# Altium schematic sheet dsPIC Click Analog v1.6.SchDoc
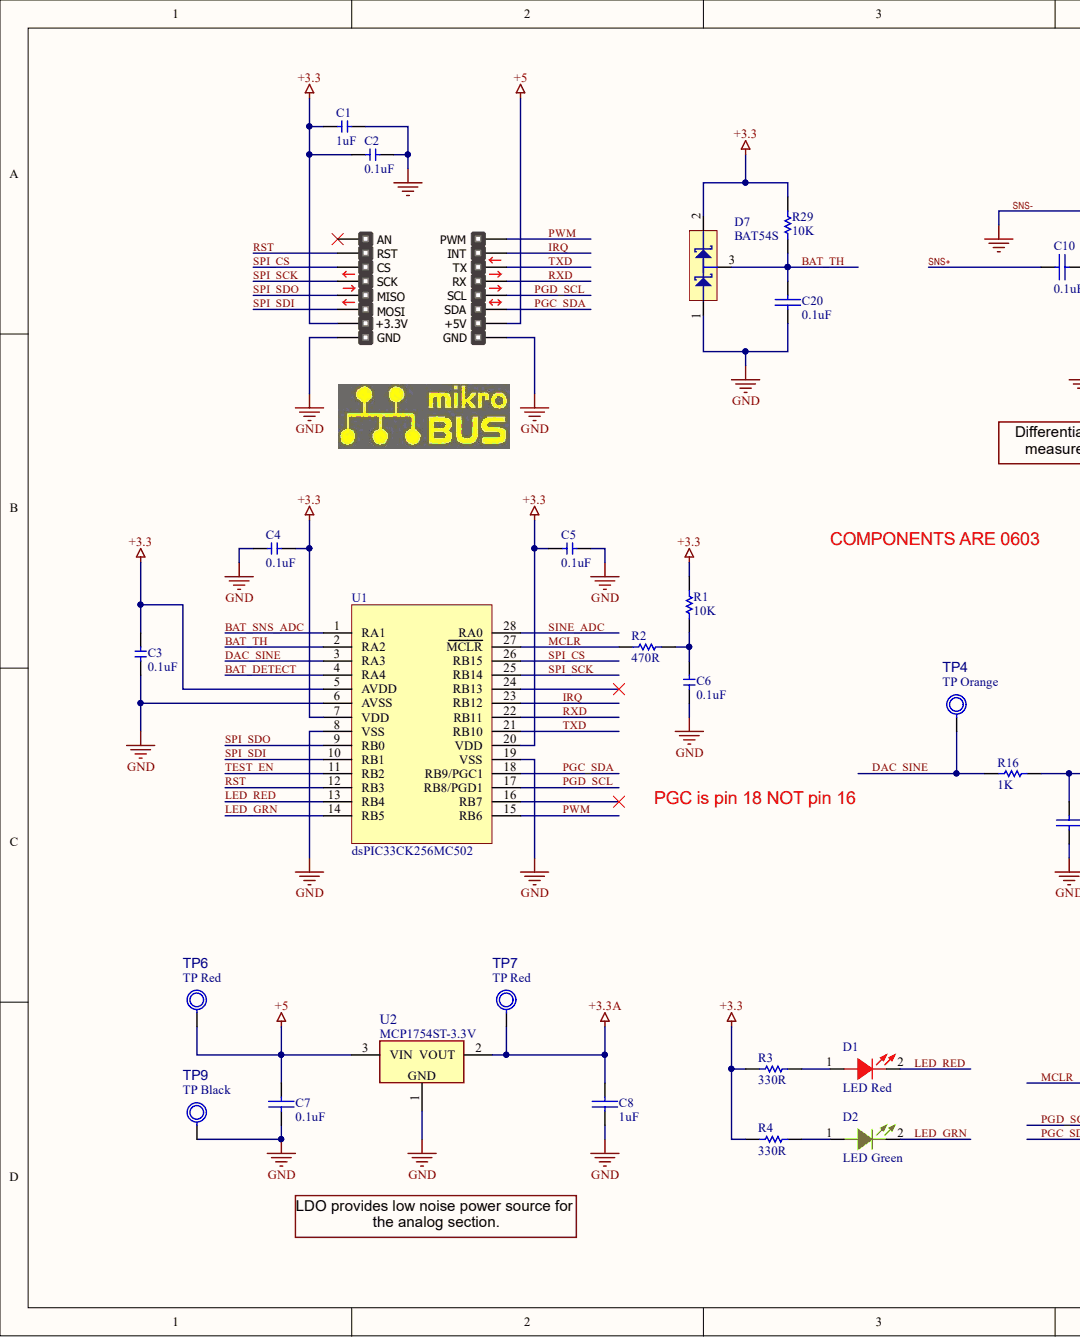
[diagram p0: dsPIC Click Analog v1.6.SchDoc, left]
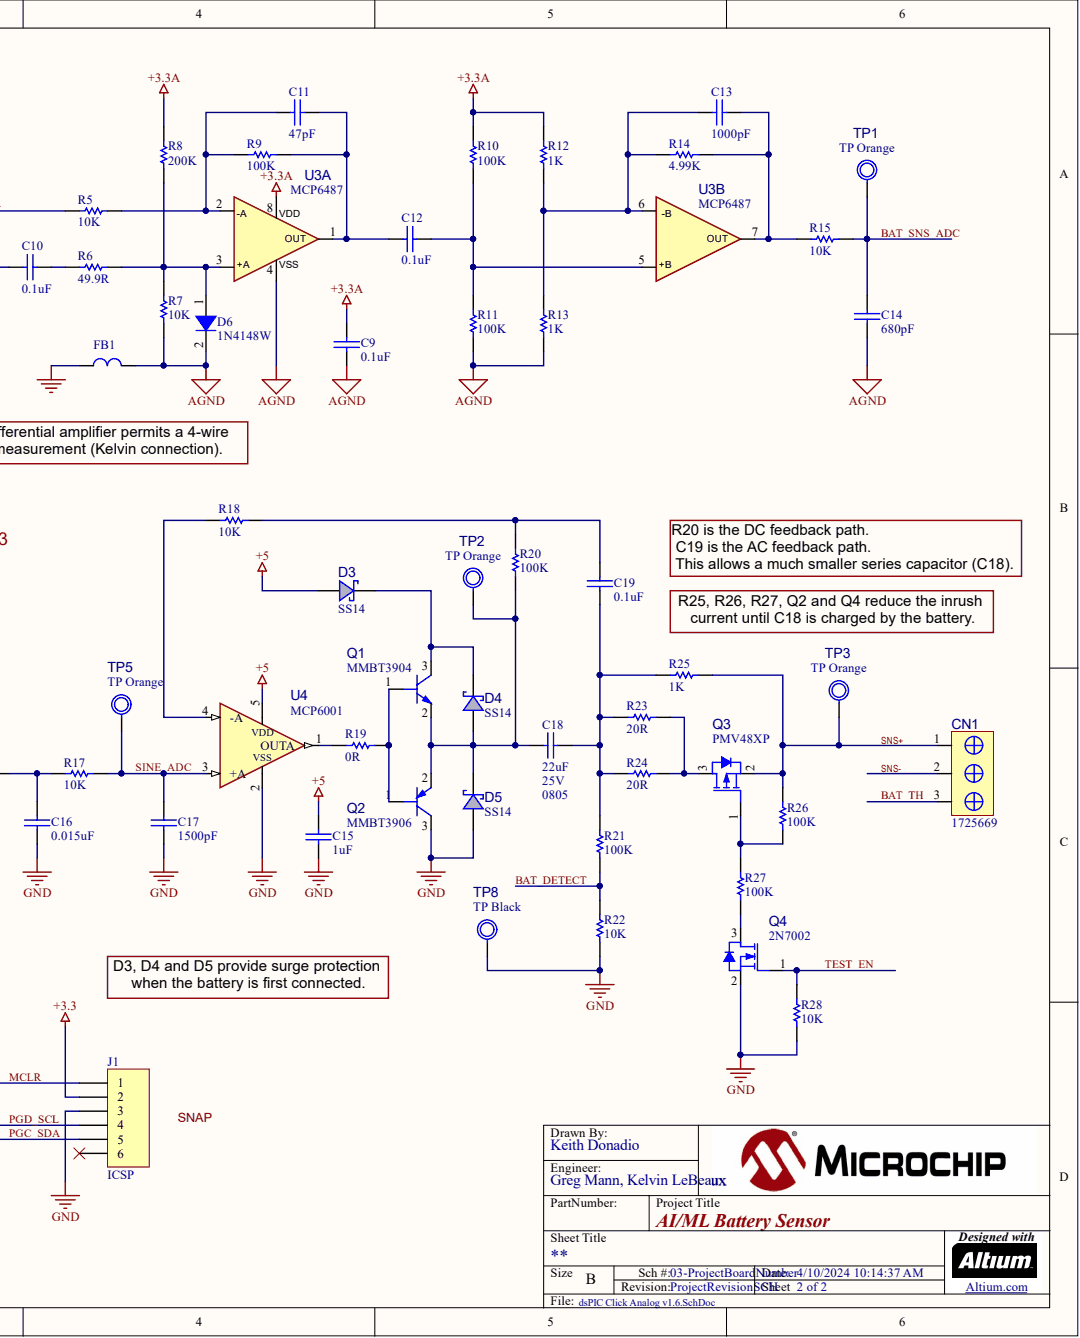
[diagram p1: dsPIC Click Analog v1.6.SchDoc, right]

## Parts
C1  1uF  [0603C]
C2  0.1uF  [0603C]
C3  0.1uF  [0603C]
C4  0.1uF  [0603C]
C5  0.1uF  [0603C]
C6  0.1uF  [0603C]
C7  0.1uF  [0603C]
C8  1uF  [0603C]
C9  0.1uF  [0603C]
C10  0.1uF  [0603C]
C11  47pF  [0603C]
C12  0.1uF  [0603C]
C13  1000pF  [0603C]
C14  680pF  [0603C]
C15  1uF  [0603C]
C16  0.015uF  [0603C]
C17  1500pF  [0603C]
C18  22uF  [0805C]
C19  0.1uF  [0603C]
C20  0.1uF  [0603C]
CN1  1725669  [1725669]
D1  LED Red  [LTST-C171]
D2  LED Green  [LTST-C171]
D3  SS14  [SMA-FL]
D4  SS14  [SMA-FL]
D5  SS14  [SMA-FL]
D6  1N4148W  [SOD-123]
D7  BAT54S  [SOT-23]
FB1  FB  [0603FB]
J1  ICSP  [HDR1X6]
MIKROBUS_CONNECTOR  MIKROBUS DEVICE CONN.  [MIKRO_BUS_dsPIC_T]  (value not drawn)
Q1  MMBT3904  [SOT-23]
Q2  MMBT3906  [SOT-23]
Q3  PMV48XP  [SOT-23]
Q4  2N7002  [SOT-23]
R1  10K  [0603R]
R2  470R  [0603R]
R3  330R  [0603R]
R4  330R  [0603R]
R5  10K  [0603R]
R6  49.9R  [0603R]
R7  10K  [0603R]
R8  200K  [0603R]
R9  100K  [0603R]
R10  100K  [0603R]
R11  100K  [0603R]
R12  1K  [0603R]
R13  1K  [0603R]
R14  4.99K  [0603R]
R15  10K  [0603R]
R16  1K  [0603R]
R17  10K  [0603R]
R18  10K  [0603R]
R19  0R  [0603R]
R20  100K  [0603R]
R21  100K  [0603R]
R22  10K  [0603R]
R23  20R  [0603R]
R24  20R  [0603R]
R25  1K  [0603R]
R26  100K  [0603R]
R27  100K  [0603R]
R28  10K  [0603R]
R29  10K  [0603R]
TP1  Test Point Orange  [TP_KEYSTONE_5003]  (value not drawn)
TP2  Test Point Orange  [TP_KEYSTONE_5003]  (value not drawn)
TP3  Test Point Orange  [TP_KEYSTONE_5003]  (value not drawn)
TP4  Test Point Orange  [TP_KEYSTONE_5003]  (value not drawn)
TP5  Test Point Orange  [TP_KEYSTONE_5003]  (value not drawn)
TP6  Test Point Red  [TP_KEYSTONE_5000]  (value not drawn)
TP7  Test Point Red  [TP_KEYSTONE_5000]  (value not drawn)
TP8  Test Point Black  [TP_KEYSTONE_5001]  (value not drawn)
TP9  Test Point Black  [TP_KEYSTONE_5001]  (value not drawn)
U1  dsPIC33CK256MC502  [SSOP-28]
U2  MCP1754ST-3.3V  [SOT-23]
U3  MCP6487  [SOIC-8]  (parts A,B)
U4  MCP6001  [TSOP-5]
  MikroBusLogo  [MB_Large]  (value not drawn)

## Nets
+3.3: C1.1 C2.1 C3.2 C4.1 C5.2 D7.2 J1.2 MIKROBUS_CONNECTOR.7(+3.3V) R1.2 R3.2 R4.2 R29.2 U1.5(AVDD) U1.7(VDD) U1.20(VDD)
+3.3A: C8.1 C9.1 R8.2 R10.2 R12.2 TP7.1 U2.2 U3.8
+5: C7.1 C15.1 D3.1 MIKROBUS_CONNECTOR.10(+5V) TP6.1 U2.3 U4.5
AGND: C9.2 C14.1 D6.2 FB1.2 R7.1 R11.1 R13.1 U3.4
BAT_DETECT: R21.1 R22.2 U1.4(RA4)
BAT_SNS_ADC: C14.2 R15.1 TP1.1 U1.1(RA1)
BAT_TH: C20.1 CN1.3 D7.3 R29.1 U1.2(RA2)
DAC_SINE: R16.1 TP4.1 U1.3(RA3)
IRQ: MIKROBUS_CONNECTOR.15(INT) U1.23(RB12)
LED_GRN: D2.2 U1.14(RB5)
LED_RED: D1.2 U1.13(RB4)
MCLR: J1.1 R2.2 U1.27(MCLR)
PGC_SDA: J1.5 MIKROBUS_CONNECTOR.11(SDA) U1.18(RB9/PGC1)
PGD_SCL: J1.4 MIKROBUS_CONNECTOR.12(SCL) U1.17(RB8/PGD1)
PWM: MIKROBUS_CONNECTOR.16(PWM) U1.15(RB6)
RST: MIKROBUS_CONNECTOR.2(RST) U1.12(RB3)
RXD: MIKROBUS_CONNECTOR.13(RX) U1.22(RB11)
SINE_ADC: C17.1 R17.2 TP5.1 U1.28(RA0) U4.3(+A)
SNS+: C10.1 CN1.1 Q3.2 R25.1 R26.1 TP3.1
SNS-: C1.2 C2.2 C3.1 C4.2 C5.1 C6.2 C7.2 C8.2 C15.2 C16.2 C17.2 C20.2 CN1.2 D5.1 D7.1 FB1.1 J1.3 MIKROBUS_CONNECTOR.8(GND) MIKROBUS_CONNECTOR.9(GND) Q2.3 Q4.2 R5.1 R22.1 R28.2 TP8.1 TP9.1 U1.6(AVSS) U1.8(VSS) U1.19(VSS) U2.1 U4.2
SPI_CS: MIKROBUS_CONNECTOR.3(CS) U1.26(RB15)
SPI_SCK: MIKROBUS_CONNECTOR.4(SCK) U1.25(RB14)
SPI_SDI: MIKROBUS_CONNECTOR.6(MOSI) U1.10(RB1)
SPI_SDO: MIKROBUS_CONNECTOR.5(MISO) U1.9(RB0)
TEST_EN: Q4.1 R28.1 U1.11(RB2)
TXD: MIKROBUS_CONNECTOR.14(TX) U1.21(RB10)
NetC6_1: C6.1 R1.1 R2.1
NetC10_2: C10.2 R6.1
NetC11_1: C11.1 R5.2 R9.2 U3.2(-A)
NetC11_2: C11.2 C12.1 R9.1 U3.1(OUT)
NetC12_2: C12.2 R10.1 R11.2 U3.5(+B)
NetC13_1: C13.1 R12.1 R13.2 R14.2 U3.6(-B)
NetC13_2: C13.2 R14.1 R15.2 U3.7(OUT)
NetC16_1: C16.1 R16.2 R17.1
NetC18_1: C18.1 D4.1 D5.2 Q1.2 Q2.2 R20.1 TP2.1
NetC18_2: C18.2 C19.2 R21.2 R23.2 R24.2 R25.2
NetC19_1: C19.1 R18.2 R20.2
NetD1_1: D1.1 R3.1
NetD2_1: D2.1 R4.1
NetD3_2: D3.2 D4.2 Q1.3
NetD6_1: D6.1 R6.2 R7.2 R8.1 U3.3(+A)
NetQ1_1: Q1.1 Q2.1 R19.2
NetQ3_1: Q3.1 R26.2 R27.1
NetQ3_3: Q3.3 R23.1 R24.1
NetQ4_3: Q4.3 R27.2
NetR18_1: R18.1 U4.4(-A)
NetR19_1: R19.1 U4.1(OUTA)

Not connected: J1 6; MIKROBUS_CONNECTOR 1(AN); U1 16(RB7) 24(RB13)

## Library data of the parts
C1-C6 = (no symbol name): Capacitor; Type: Ceramic; Category: Capacitor; Component Kind: Standard; ComponentLink1Description: Datasheet; Library Name: dsPIC Click_2.SCHLIB; Operating Temperature: -55C to +125C; Quantity Override: 1; Revision: 0
C7-C20 = CAP: Capacitor; Component Kind: Standard; Library Name: dsPIC Click_2.SCHLIB
  C18: : 0805; : 25V
CN1 = (no symbol name): Terminal Block, 3-Position; Manufacturer 1: Phoenix Contact; Manufacturer Part Number 1: 1725672; Current: 6A; Description_: CON TERMINAL 2.54mm 1x4 Female 20-30AWG 6A TH R/A; Dev Tools Status: Production; MCHP-ID: CON0005; Owner: Sorin Stanoaia; Populated: YES; Symbol: Header 1x4; Value: TERMINAL 1x4; Voltage: 63V; Category: Connector; Component Kind: Standard; ComponentLink1Description: SVN Datasheet; ComponentLink1URL: https://chn-vm-apps.microchip.com/mchprepo/parts/Datasheets/1725672.pdf; ComponentLink2Description: Local Datasheet; ComponentLink3Description: Open Symbol; ComponentLink4Description: Open Footprint; ComponentLink5Description: Open Footprint 2; ComponentLink6Description: Open Footprint 3; ComponentLink7Description: Open Footprint 4; Library Name: dsPIC Click_2.SCHLIB; Manufacturer 2: Degson Electronics Co., Ltd.; Manufacturer Part Number 2: DG308-2.54-04P-14-00A(H); Quantity Override: 1; Revision: 0; Status: MCL Design; Supplier 1: Digi-Key; Supplier 2: Raynen; Supplier Part Number 1: 277-1275-ND; Supplier Part Number 2: CONN00186B01M; Type: Terminal Block; ComponentLink2URL: C:\ALTIUM_WORK\00001_MCHP-CDB\Datasheets\1725672.pdf; ComponentLink3URL: C:\ALTIUM_WORK\00001_MCHP-CDB\Library\LibraryRefs\Header 1x4.SchLib; ComponentLink4URL: C:\ALTIUM_WORK\00001_MCHP-CDB\Library\Footprints\CON_TERMINAL_2.54_1x4_TH_RA_PHO_1725672.PcbLib
D1 = (no symbol name): Red LED; Manufacturer 1: Lumex; Manufacturer Part Number 1: SML-LXT0805IW-TR; Description_: DIO LED RED 2V 30mA 0805; Forward Current: 30mA; MCHP-ID: LED1020; Owner: Stephen Humberd; Populated: YES; Power: 50mW; Reverse Current: 100uA; Reverse Voltage: 5V; Type: LED Single; Category: Diode; Component Kind: Standard; ComponentLink1Description: Datasheet; ComponentLink1URL: https://chn-vm-apps.microchip.com/mchprepo/parts/Datasheets/SML-LXT0805IW-TR.pdf; Library Name: dsPIC Click_2.SCHLIB; Operating Temperature: -25C to +80C; Package: 0805; Quantity Override: 1; Revision: A; Status: Design; Supplier 1: Digi-Key; Supplier Part Number 1: 67-1552-1-ND; Value: RED
D2 = (no symbol name): Green LED; Manufacturer 1: Lumex; Manufacturer Part Number 1: SML-LXT0805IW-TR; Description_: DIO LED RED 2V 30mA 0805; Forward Current: 30mA; MCHP-ID: LED1020; Owner: Stephen Humberd; Populated: YES; Power: 50mW; Reverse Current: 100uA; Reverse Voltage: 5V; Type: LED Single; Value: GRN; Category: Diode; Component Kind: Standard; ComponentLink1Description: Datasheet; ComponentLink1URL: https://chn-vm-apps.microchip.com/mchprepo/parts/Datasheets/SML-LXT0805IW-TR.pdf; Library Name: dsPIC Click_2.SCHLIB; Operating Temperature: -25C to +80C; Package: 0805; Quantity Override: 1; Revision: A; Status: Design; Supplier 1: Digi-Key; Supplier Part Number 1: 67-1552-1-ND
D3-D5 = (no symbol name): Diode, Schottky; Manufacturer 1: Fairchild; Description_: DIO SCTKY SS14 500mV 1A 40V DO-214AC_SMA; Dev Tools Status: Production; Forward Current: 1A; MCHP-ID: DIODE0128; Populated: YES; Power: 1.1W; Reverse Current: 200uA; Reverse Voltage: 40V; Symbol: Diode Schottky; Type: Schottky Single; Category: Diode; Component Kind: Standard; ComponentLink1Description: SVN Datasheet; ComponentLink1URL: https://chn-vm-apps.microchip.com/mchprepo/parts/Datasheets/SS12.pdf; ComponentLink2Description: Local Datasheet; ComponentLink3Description: Open Symbol; ComponentLink4Description: Open Footprint; ComponentLink5Description: Open Footprint 2; ComponentLink6Description: Open Footprint 3; ComponentLink7Description: Open Footprint 4; Library Name: dsPIC Click_2.SCHLIB; Manufacturer Part Number 1: SS14; Operating Temperature: -65C to +125C; Package: DO-214AC_SMA; Quantity Override: 1; Revision: 1; Status: MCL Design; Supplier 1: Digi-Key; Supplier Part Number 1: SS14CT-ND; ComponentLink2URL: C:\ALTIUM_WORK\00001_MCHP-CDB\Datasheets\SS12.pdf; ComponentLink3URL: C:\ALTIUM_WORK\00001_MCHP-CDB\Library\LibraryRefs\Diode Schottky.SchLib; ComponentLink4URL: C:\ALTIUM_WORK\00001_MCHP-CDB\Library\Footprints\DO-214AC_SMA.PcbLib
D6 = 1N4148W: 1N4148W, SOD-123; Component Kind: Standard; Library Name: dsPIC Click_2.SCHLIB
D7 = BAT54S: Schottky Diode, Dual, Common Cathode; Code_JEDEC: DO-214-AA; Component Kind: Standard; LatestRevisionDate: 17-Jul-2002; LatestRevisionNote: Re-released for DXP Platform.; Library Name: dsPIC Click_2.SCHLIB; PackageDescription: DO-214-AA/SMB; 2 C-Bend Leads; Body 5.3 x 3.6 mm, inc. leads (LxW); PackageReference: SMB; Published: 8-Jun-2000; Publisher: Altium Limited
FB1 = (no symbol name): Ferrite Bead; Manufacturer 1: TDK; Manufacturer Part Number 1: FLF3215T-4R7M; DCR: 0.09R; Description_: INDUCTOR 4.7uH 1A 20% SMD 1210; MCHP-ID: IND0071; Populated: Yes; Saturation Current: 1A; Test Frequency: 1MHz; Type: Inductor; Category: Inductor; Component Kind: Standard; ComponentLink1Description: Datasheet; ComponentLink1URL: https://chn-vm-apps.microchip.com/mchprepo/parts/Datasheets/e531_flf3215.pdf; Library Name: dsPIC Click_2.SCHLIB; Operating Temperature: -40C to +125C; Package: 1210; Quantity Override: 1; Revision: 1; Status: Production; Supplier 1: Digi-Key; Supplier Part Number 1: 445-4846-2-ND
J1 = Header 6: Header, 6-Pin; Component Kind: Standard; LatestRevisionDate: 17-Jul-2002; LatestRevisionNote: Re-released for DXP Platform.; Library Name: dsPIC Click_2.SCHLIB; Publisher: Altium Limited
MIKROBUS_CONNECTOR = MIKROBUS DEVICE CONN.: mikroBUS Target Header; Component Kind: Standard; Library Name: dsPIC Click_2.SCHLIB
Q1 = MMBT3904: Transistor, NPN; Manufacturer 1: Diodes Incorporated; Manufacturer Part Number 1: MMBT3904-7; Current: 200mA; Description_: TRANS BJT NPN MMBT3904 40V 200mA 310mW SOT-23-3; Dev Tools Status: Production; MCHP-ID: TRA0006; Populated: Yes; Power: 310mW; Symbol: Transistor NPN BEC; Type: BJT; Voltage: 40V; Category: Transistor; Component Kind: Standard; ComponentLink1Description: SVN Datasheet; ComponentLink1URL: https://chn-vm-apps.microchip.com/mchprepo/parts/Datasheets/ds30036.pdf; ComponentLink2Description: Local Datasheet; ComponentLink3Description: Open Symbol; ComponentLink4Description: Open Footprint; ComponentLink5Description: Open Footprint 2; ComponentLink6Description: Open Footprint 3; ComponentLink7Description: Open Footprint 4; Library Name: dsPIC Click_2.SCHLIB; Manufacturer 2: Diodes Incorporated; Manufacturer 3: Fairchild; Manufacturer 4: Micro Commercial Components Corporation; Manufacturer 5: NXP Semiconductors; Manufacturer 6: ON Semiconductor; Manufacturer 7: ON Semiconductor; Manufacturer 8: ON Semiconductor; Manufacturer 9: STMicroelectronics; Manufacturer Part Number 2: MMBT3904-7-F; Manufacturer Part Number 3: MMBT3904; Manufacturer Part Number 4: MMBT3904-TP; Manufacturer Part Number 5: PMBT3904,215; Manufacturer Part Number 6: MMBT3904LT1G; Manufacturer Part Number 7: MMBT3904LT3G; Manufacturer Part Number 8: MMBT3904LT3; Manufacturer Part Number 9: MMBT3904; Operating Temperature: -55C to +150C; Package: SOT-23-3; Quantity Override: 1; Revision: 3; Status: MCL Design; Supplier 1: Digi-Key; Supplier 2: Digi-Key; Supplier 3: Digi-Key; Supplier 4: Digi-Key; Supplier 5: Digi-Key; Supplier 6: Digi-Key; Supplier 7: Digi-Key; Supplier 8: Digi-Key; Supplier 9: Digi-Key; Supplier 10: Digi-Key; Supplier Part Number 1: MMBT3904TPMSCT-ND; Supplier Part Number 2: MMBT3904-FDITR-ND; Supplier Part Number 3: MMBT3904-FDICT-ND; Supplier Part Number 4: MMBT3904FSTR-ND; Supplier Part Number 5: MMBT3904TPMSCT-ND; Supplier Part Number 6: 568-1741-1-ND; Supplier Part Number 7: MMBT3904LT1GOSCT-ND; Supplier Part Number 8: MMBT3904LT3GOSTR-ND; Supplier Part Number 9: MMBT3904LT3OSCT-ND; Supplier Part Number 10: 497-2478-2-ND; ComponentLink2URL: C:\ALTIUM_WORK\00001_MCHP-CDB\Datasheets\ds30036.pdf; ComponentLink3URL: C:\ALTIUM_WORK\00001_MCHP-CDB\Library\LibraryRefs\Transistor NPN BEC.SchLib; ComponentLink4URL: C:\ALTIUM_WORK\00001_MCHP-CDB\Library\Footprints\SOT-23-3.PcbLib
Q2 = MMBT3906: Transistor, PNP; Manufacturer 1: NXP Semiconductors; Manufacturer Part Number 1: PMBT3906,215; Current: -200mA; Description_: TRANS BJT PNP MMBT3906 -40V -200mA 300mW SOT-23-3; Dev Tools Status: Production; MCHP-ID: TRA0015; Populated: YES; Power: 300mW; Symbol: Transistor PNP BEC; Type: BJT; Voltage: -40V; Category: Transistor; Component Kind: Standard; ComponentLink1Description: SVN Datasheet; ComponentLink1URL: https://chn-vm-apps.microchip.com/mchprepo/parts/Datasheets/ds30059.pdf; ComponentLink2Description: Local Datasheet; ComponentLink3Description: Open Symbol; ComponentLink4Description: Open Footprint; ComponentLink5Description: Open Footprint 2; ComponentLink6Description: Open Footprint 3; ComponentLink7Description: Open Footprint 4; Library Name: dsPIC Click_2.SCHLIB; Manufacturer 2: Fairchild; Manufacturer 3: Micro Commercial Components Corporation; Manufacturer 4: Central Semiconductor; Manufacturer 5: Diodes Incorporated; Manufacturer 6: Diodes Incorporated; Manufacturer 7: ON Semiconductor; Manufacturer 8: Fairchild; Manufacturer Part Number 2: MMBT3906; Manufacturer Part Number 3: MMBT3906-TP; Manufacturer Part Number 4: CMPT3906-PST-LEADFREE; Manufacturer Part Number 5: MMBT3906-7; Manufacturer Part Number 6: MMBT3906-7-F; Manufacturer Part Number 7: MMBT3906LT1G; Manufacturer Part Number 8: MMBT3906K; Operating Temperature: -55C to +150C; Package: SOT-23-3; Quantity Override: 1; Revision: 2; Status: MCL Design; Supplier 1: Digi-Key; Supplier 2: Digi-Key; Supplier 3: Digi-Key; Supplier 4: Central Semiconductor; Supplier 5: Digi-Key; Supplier 6: Digi-Key; Supplier 7: Diodes Incorporated; Supplier 8: Digi-Key; Supplier 9: Fairchild; Supplier Part Number 1: 568-1742-1-ND; Supplier Part Number 2: MMBT3906FSTR-ND; Supplier Part Number 3: MMBT3906-TPCT-ND; Supplier Part Number 4: CMPT3906-PST-LEADFREE; Supplier Part Number 5: MMBT3906DITR-ND; Supplier Part Number 6: MMBT3906-FDICT-ND; Supplier Part Number 7: MMBT3906-7-F; Supplier Part Number 8: MMBT3906LT1GOSTR-ND; Supplier Part Number 9: MMBT3906K; ComponentLink2URL: C:\ALTIUM_WORK\00001_MCHP-CDB\Datasheets\ds30059.pdf; ComponentLink3URL: C:\ALTIUM_WORK\00001_MCHP-CDB\Library\LibraryRefs\Transistor PNP BEC.SchLib; ComponentLink4URL: C:\ALTIUM_WORK\00001_MCHP-CDB\Library\Footprints\SOT-23-3.PcbLib
Q3 = PMV48XP: MOSFET, P-Channel; Manufacturer 1: NXP Semiconductors; Manufacturer Part Number 1: PMV48XP,215; Current: 3.5A; Description_: TRANS FET P-CH PMV48XP,215 20V 3.5A 510mW SOT-23-3; Dev Tools Status: Design; Drain to Source Voltage: 20V; Gate to Source Voltage: 12V; MCHP-ID: TRA1141; Owner: Gabor Bihari; Populated: YES; Power: 510mW; Symbol: Transistor MOS-P GSD; Type: FET; Category: Transistor; Component Kind: Standard; ComponentLink1Description: SVN Datasheet; ComponentLink1URL: https://chn-vm-apps.microchip.com/mchprepo/parts/Datasheets/PMV48XP.pdf; ComponentLink2Description: Local Datasheet; ComponentLink3Description: Open Symbol; ComponentLink4Description: Open Footprint; ComponentLink5Description: Open Footprint 2; ComponentLink6Description: Open Footprint 3; ComponentLink7Description: Open Footprint 4; Library Name: dsPIC Click_2.SCHLIB; Operating Temperature: -55°C to 150°C; Package: SOT-23-3; Quantity Override: 1; Revision: A; Status: MCL Design; Supplier 1: Digi-Key; Supplier Part Number 1: 568-7579-1-ND; ComponentLink2URL: C:\ALTIUM_WORK\00001_MCHP-CDB\Datasheets\PMV48XP.pdf; ComponentLink3URL: C:\ALTIUM_WORK\00001_MCHP-CDB\Library\LibraryRefs\Transistor MOS-P GSD.SchLib; ComponentLink4URL: C:\ALTIUM_WORK\00001_MCHP-CDB\Library\Footprints\SOT-23-3.PcbLib
Q4 = 2N7002: MOSFET; Manufacturer 1: Diodes Inc; Manufacturer Part Number 1: 2N7002-7-F; Current: 170mA; Description_: TRANS FET N-CH 2N7002-7-F 60V 170mA 370mW SOT-23-3; Dev Tools Status: Production; Drain to Source Voltage: 60V; Gate to Source Voltage: 20V; MCHP-ID: TRA1102; Owner: Adrian M (M91404); Populated: YES; Power: 370mW; Symbol: Transistor MOS-N GSD; Type: FET; Category: Transistor; Component Kind: Standard; ComponentLink1Description: SVN Datasheet; ComponentLink1URL: https://chn-vm-apps.microchip.com/mchprepo/parts/Datasheets/ds11303.pdf; ComponentLink2Description: Local Datasheet; ComponentLink3Description: Open Symbol; ComponentLink4Description: Open Footprint; ComponentLink5Description: Open Footprint 2; ComponentLink6Description: Open Footprint 3; ComponentLink7Description: Open Footprint 4; Library Name: dsPIC Click_2.SCHLIB; Operating Temperature: -55C to +150C; Package: SOT-23-3; Quantity Override: 1; Revision: 0; Status: MCL Design; Supplier 1: Digi-Key; Supplier Part Number 1: 2N7002-FDICT-ND; ComponentLink2URL: C:\ALTIUM_WORK\00001_MCHP-CDB\Datasheets\ds11303.pdf; ComponentLink3URL: C:\ALTIUM_WORK\00001_MCHP-CDB\Library\LibraryRefs\Transistor MOS-N GSD.SchLib; ComponentLink4URL: C:\ALTIUM_WORK\00001_MCHP-CDB\Library\Footprints\SOT-23-3.PcbLib
R1-R29 = Res1: Resistor; Component Kind: Standard; LatestRevisionDate: 17-Jul-2002; LatestRevisionNote: Re-released for DXP Platform.; Library Name: dsPIC Click_2.SCHLIB; PackageDescription: Axial Device, Thru-Hole; 2 Leads; 0.3 in Pin Spacing; PackageReference: AXIAL-0.3; Published: 8-Jun-2000; Publisher: Altium Limited
TP1-TP5 = (no symbol name): Test Point, 5003; : TP Orange; Component Kind: Standard; Library Name: dsPIC Click_2.SCHLIB; Revision: 0
TP6 TP7 = (no symbol name): Test Point, 5000; : TP Red; Component Kind: Standard; Library Name: dsPIC Click_2.SCHLIB; Revision: 0
TP8 TP9 = (no symbol name): Test Point, 5001; : TP Black; Component Kind: Standard; Library Name: dsPIC Click_2.SCHLIB; Revision: 0
U1 = dsPIC33CK256MC502_CORRECTED: 16-bit MCU; Component Kind: Standard; Library Name: dsPIC Click_2.SCHLIB
U2 = (no symbol name): LDO; Manufacturer 1: Microchip Technology; Manufacturer Part Number 1: MCP1754ST-3302E/CB; Alarm_Mem: False; Description_: MCHP ANALOG LDO 3.3V MCP1754ST-3302E/CB SOT-23A-3; Dev Tools Status: Production; LeadCount: 3; MAPS Description: 16V, High PSRR, 150mA LDO, Vout=3.3V; MCHP-ID: MIC7325; MPC: V0AB2YM7XB00; Owner: Iulian Margarit; PackingMedia: T/R; Populated: YES; Symbol: MCP1754S SOT-23A-3; tblDeviceFamilyID: 3163; TempRange: -40C to +125C; Brown_Out: False; Category: MCHP-Analog; Chamber_Test: False; Charge_Pump: False; Component Kind: Standard; ComponentLink1Description: SVN Datasheet; ComponentLink1URL: http://www.microchip.com/wwwproducts/MCP1754S; ComponentLink2Description: Local Datasheet; ComponentLink3Description: Open Symbol; ComponentLink4Description: Open Footprint; ComponentLink5Description: Open Footprint 2; ComponentLink6Description: Open Footprint 3; ComponentLink7Description: Open Footprint 4; EncryptAlg: False; Inductive_Boost: False; InputChEnable: False; Interconnect: False; IntroductionDate: 2011-08-16; LED_Driver: False; LFTalkFeture: False; Library Name: dsPIC Click_2.SCHLIB; Low_Bat: False; Low_Batt: False; PackageType: SOT-23A; Power_Good: False; ProgWkUpFilter: False; Quantity Override: 1; RailIn: False; RailOut: False; Rev_Batt_Prot: False; Revision: 1; Sens_Timer: False; Status: MCL Design; Supplier 1: Digi-Key; Supplier Part Number 1: MCP1754ST-3302E/CBCT-ND; Type: LDO; WkUpPtnEnable: False; ComponentLink3URL: C:\ALTIUM_WORK\00001_MCHP-CDB\Library\LibraryRefs\MCP1754S SOT-23A-3.SchLib; ComponentLink4URL: C:\ALTIUM_WORK\00001_MCHP-CDB\Library\Footprints\SOT-23A-3.PcbLib
U3 = (no symbol name): OPAMP, Dual; Manufacturer 1: Microchip; Manufacturer Part Number 1: MCP6002T-I/SN; Alarm_Mem: False; Amplifiers: 2; Description_: MCHP ANALOG OPAMP 2-Ch 1MHz MCP6002T-I/SN SOIC-8; Dev Tools Status: Production; GBWP_KHz: 1000; IqMax: 170; LeadCount: 8; MAPS Description: Dual 1.8V, 1MHz OP, I temp; MCHP-ID: MIC0395; MPC: ABBZ1TC2XA00; PackageWidth: 3.90mm(.150in); PackingMedia: T/R; Populated: Yes; RailIn: True; StableGainMin: 1; Symbol: MCP6XX2_7 SOIC-8_MSOP-8_PDIP-8; tblDeviceFamilyID: 263; TempRange: -40C to +85C; VDDmax: 5.5; VDDmin: 1.8; VosMax: 4500; Brown_Out: False; Category: MCHP-Analog; Chamber_Test: False; Charge_Pump: False; Component Kind: Standard; ComponentLink1Description: SVN Datasheet; ComponentLink1URL: http://www.microchip.com/wwwproducts/MCP6002; ComponentLink2Description: Local Datasheet; ComponentLink3Description: Open Symbol; ComponentLink4Description: Open Footprint; ComponentLink5Description: Open Footprint 2; ComponentLink6Description: Open Footprint 3; ComponentLink7Description: Open Footprint 4; EncryptAlg: False; Inductive_Boost: False; InputChEnable: False; Interconnect: False; IntroductionDate: 2012-06-28; LED_Driver: False; LFTalkFeture: False; Library Name: dsPIC Click_2.SCHLIB; Low_Bat: False; Low_Batt: False; Manufacturer 2: Microchip; Manufacturer Part Number 2: MCP6002-I/SN; PackageType: SOIC; Power_Good: False; ProgWkUpFilter: False; Quantity Override: 1; RailOut: True; Rev_Batt_Prot: False; Revision: 1; Sens_Timer: False; Status: MCL Design; Supplier 1: Microchip; Supplier 2: Microchip; Supplier Part Number 1: MCP6002T-I/SN; Supplier Part Number 2: MCP6002-I/SN; Type: OpAmp; WkUpPtnEnable: False; ComponentLink3URL: C:\ALTIUM_WORK\00001_MCHP-CDB\Library\LibraryRefs\MCP6XX2_7 SOIC-8_MSOP-8_PDIP-8.SchLib; ComponentLink4URL: C:\ALTIUM_WORK\00001_MCHP-CDB\Library\Footprints\SOIC-8.PcbLib
U4 = MCP6001: OPAMP, Single; Manufacturer 1: Microchip; Manufacturer Part Number 1: MCP6001T-E/OT; Alarm_Mem: False; Amplifiers: 1; Description_: MCHP ANALOG OPAMP 1-Ch 1MHz MCP6001T-E/OT SOT-23-5; Dev Tools Status: Production; GBWP_KHz: 1000; IqMax: 170; LeadCount: 5; MAPS Description: Single 1.8V, 1MHz OP; MCHP-ID: MIC1055; MPC: ABBY1YC7XA00; Owner: Ryan Hermens; PackingMedia: T/R; Populated: Yes; RailIn: True; Symbol: MCP6XX1_6 SOT-23-5_SC-70-5; tblDeviceFamilyID: 262; TempRange: -40C to +125C; VDDmax: 5.5; VDDmin: 1.8; VosMax: 4500; Brown_Out: False; Category: MCHP-Analog; Chamber_Test: False; Charge_Pump: False; Comments: -; Component Kind: Standard; ComponentLink1Description: SVN Datasheet; ComponentLink1URL: http://www.microchip.com/wwwproducts/MCP6001; ComponentLink2Description: Local Datasheet; ComponentLink3Description: Open Symbol; ComponentLink4Description: Open Footprint; ComponentLink5Description: Open Footprint 2; ComponentLink6Description: Open Footprint 3; ComponentLink7Description: Open Footprint 4; EncryptAlg: False; Inductive_Boost: False; InputChEnable: False; Interconnect: False; IntroductionDate: 2003-11-06; LED_Driver: False; LFTalkFeture: False; Library Name: dsPIC Click_2.SCHLIB; Low_Bat: False; Low_Batt: False; PackageType: SOT-23; Power_Good: False; ProgWkUpFilter: False; Quantity Override: 1; RailOut: True; Rev_Batt_Prot: False; Revision: 1; Sens_Timer: False; StableGainMin: 1; Status: MCL Design; Supplier 1: Microchip; Supplier Part Number 1: MCP6001T-E/OT; Type: OpAmp; WkUpPtnEnable: False; ComponentLink3URL: C:\ALTIUM_WORK\00001_MCHP-CDB\Library\LibraryRefs\MCP6XX1_6 SOT-23-5_SC-70-5.SchLib; ComponentLink4URL: C:\ALTIUM_WORK\00001_MCHP-CDB\Library\Footprints\SOT-23-5.PcbLib
 = MikroBusLogo: Logo; Component Kind: Standard; LatestRevisionDate: 17-Jul-2002; LatestRevisionNote: Re-released for DXP Platform.; Library Name: dsPIC Click_2.SCHLIB; PackageDescription: Axial Device, Thru-Hole; 2 Leads; 0.3 in Pin Spacing; PackageReference: AXIAL-0.3; Published: 8-Jun-2000; Publisher: Altium Limited
